# Altium schematic sheet USB_to_UART.SchDoc
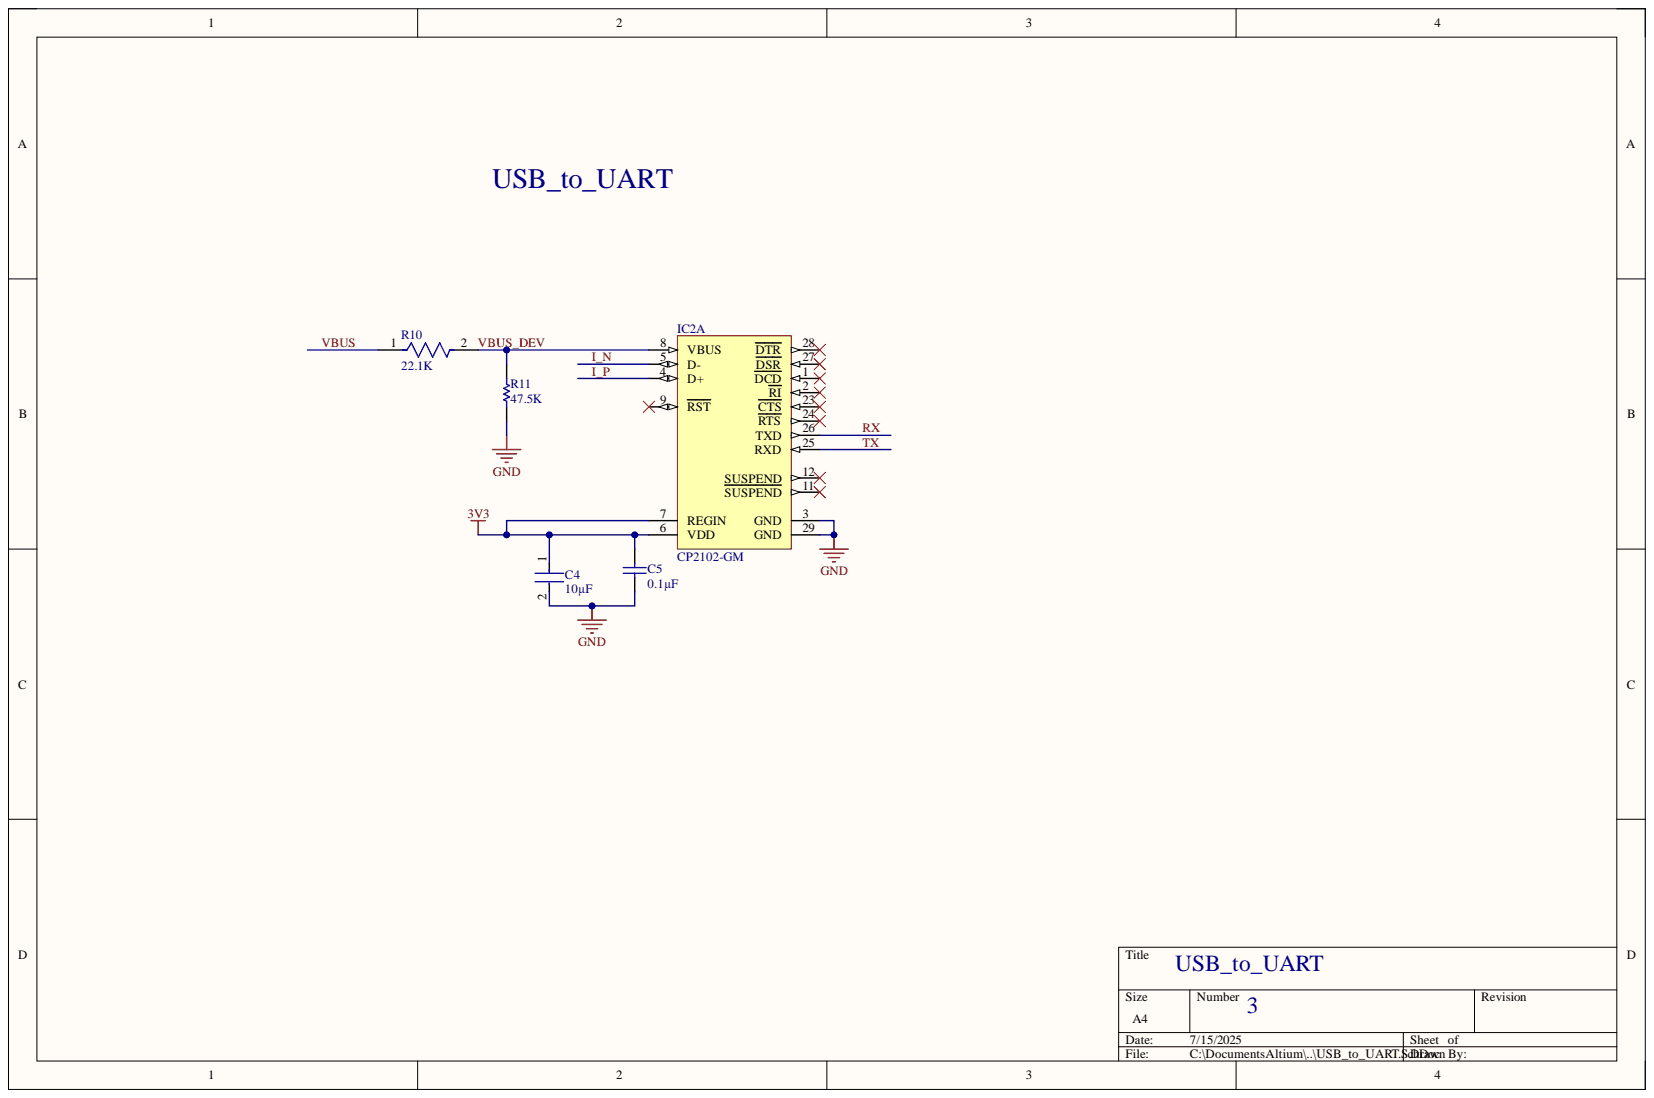
[diagram p0: USB_to_UART.SchDoc]

## Parts
C4  10µF  [FP-CC0603-0_15-0_95-IPC_B]
C5  0.1µF  [CAPC1608X87X35NL15T15]
IC2  CP2102-GM  [SLAB-QFN-28-3125x3125TP_N]  (part A)
R10  22.1K  [FP-RC0603-0_55-MFG]
R11  47.5K  [RESC1608X55X30LL15T15]

## Nets
3V3: C4.1 C5.2 IC2.6(VDD) IC2.7(REGIN)
GND: C4.2 C5.1 IC2.3(GND) IC2.29(GND) R11.1
I_N: IC2.5(D-)
I_P: IC2.4(D+)
RX: IC2.26(TXD)
TX: IC2.25(RXD)
VBUS: R10.1
VBUS_DEV: IC2.8(VBUS) R10.2 R11.2

Not connected: IC2 1(DCD) 2(RI) 9(RST) 11(SUSPEND) 12(SUSPEND) 23(CTS) 24(RTS) 27(DSR) 28(DTR)

## Library data of the parts
C4 = CMP-03422-001506-1: CAP CER 10UF 6.3V X5R 0603; Tolerance: 20%; Voltage: 4V; Capacitance: 10uF; Case Code (Imperial): 0603; Case Code (Metric): 1608; Case/Package: 0603; Component Kind: Standard; Construction: Flat; Depth: 0.8mm; Dielectric: X5R; Halogen Free: Halogen Free; Height: 0.8mm; Lead Free: Lead Free; Length: 1.6mm; Library Name: Altium Content Vault; Max Operating Temperature: 85°C; Min Operating Temperature: -55°C; Mount: Surface Mount; Packaging: Tape and Reel; Radiation Hardening: No; Resistor Type: Ceramic; RoHS Compliant: Yes; Series: CC; Termination: SMD/SMT; Thickness: 0.035inch; Voltage Rating: 6.3V; Voltage Rating (DC): 6.3V; Weight: 7.1E-05oz; Width: 0.031inch
C5 = CMP-1035-02576-2: Manufacturer: Kemet; Part Number: C0603C104K9RACTU; Component Kind: Standard; Library Name: Altium Content Vault
IC2 = CMP-0511-00012-1: Single-Chip USB to UART Bridge, 1024 Bytes EEPROM, -40 to 85 degC, 28-pin QFN, Tube; Manufacturer: Silicon Labs; Internal Oscillator: TRUE; Sub-Family: USB Bridges; Code_IPC: J-STD-020C; Code_JEDEC: MO-220; Component Kind: Standard; ComponentLink1Description: Manufacturer URL; ComponentLink1URL: http://www.silabs.com/; ComponentLink2Description: Datasheet; ComponentLink2URL: http://www.silabs.com/Support%20Documents/TechnicalDocs/CP2102-9.pdf; ComponentLink3Description: Package Specification; ComponentLink3URL: http://www.silabs.com/Support%20Documents/TechnicalDocs/C8051T61x.pdf; DatasheetVersion: Rev. 1.5; EEPROM: 1024 Bytes; Library Name: Altium Content Vault; Mounting Technology: Surface Mount; PackageDescription: 28-Pin Quad-Flat No-Leads Package, Body 5 x 5 mm, Pitch 0.5 mm; PackageReference: QFN-28; PartNumber: CP2102-GM
R10 = CMP-03412-037792-1: RES SMD 22.1K OHM 1% 1/10W 0603; Power Rating: 100mW; Resistance: 22.1kR; Temperature Coefficient: 100ppm/°C; Voltage Rating: 50V; Case Code (Imperial): 0603; Case Code (Metric): 1608; Case/Package: 0603; Component Kind: Standard; Height: 0.45mm; Lead Free: Lead Free; Length: 1.6mm; Library Name: Altium Content Vault; Max Operating Temperature: 155°C; Min Operating Temperature: -55°C; Package Quantity: 5000; Packaging: Tape and Reel; Series: RC; Size Code: 0603; Termination: SMD/SMT; Tolerance: 1%; Voltage Rating (DC): 50V; Width: 0.8mm
R11 = CMP-2000-00527-1: Manufacturer: Panasonic; Part Number: ERJ-3EKF4752V; Component Kind: Standard; Library Name: Altium Content Vault
